# Altium schematic sheet BTT E3 SKR MINI_Power_V3.02.SchDoc("BTT E3 SKR MINI_Power_V3.02")
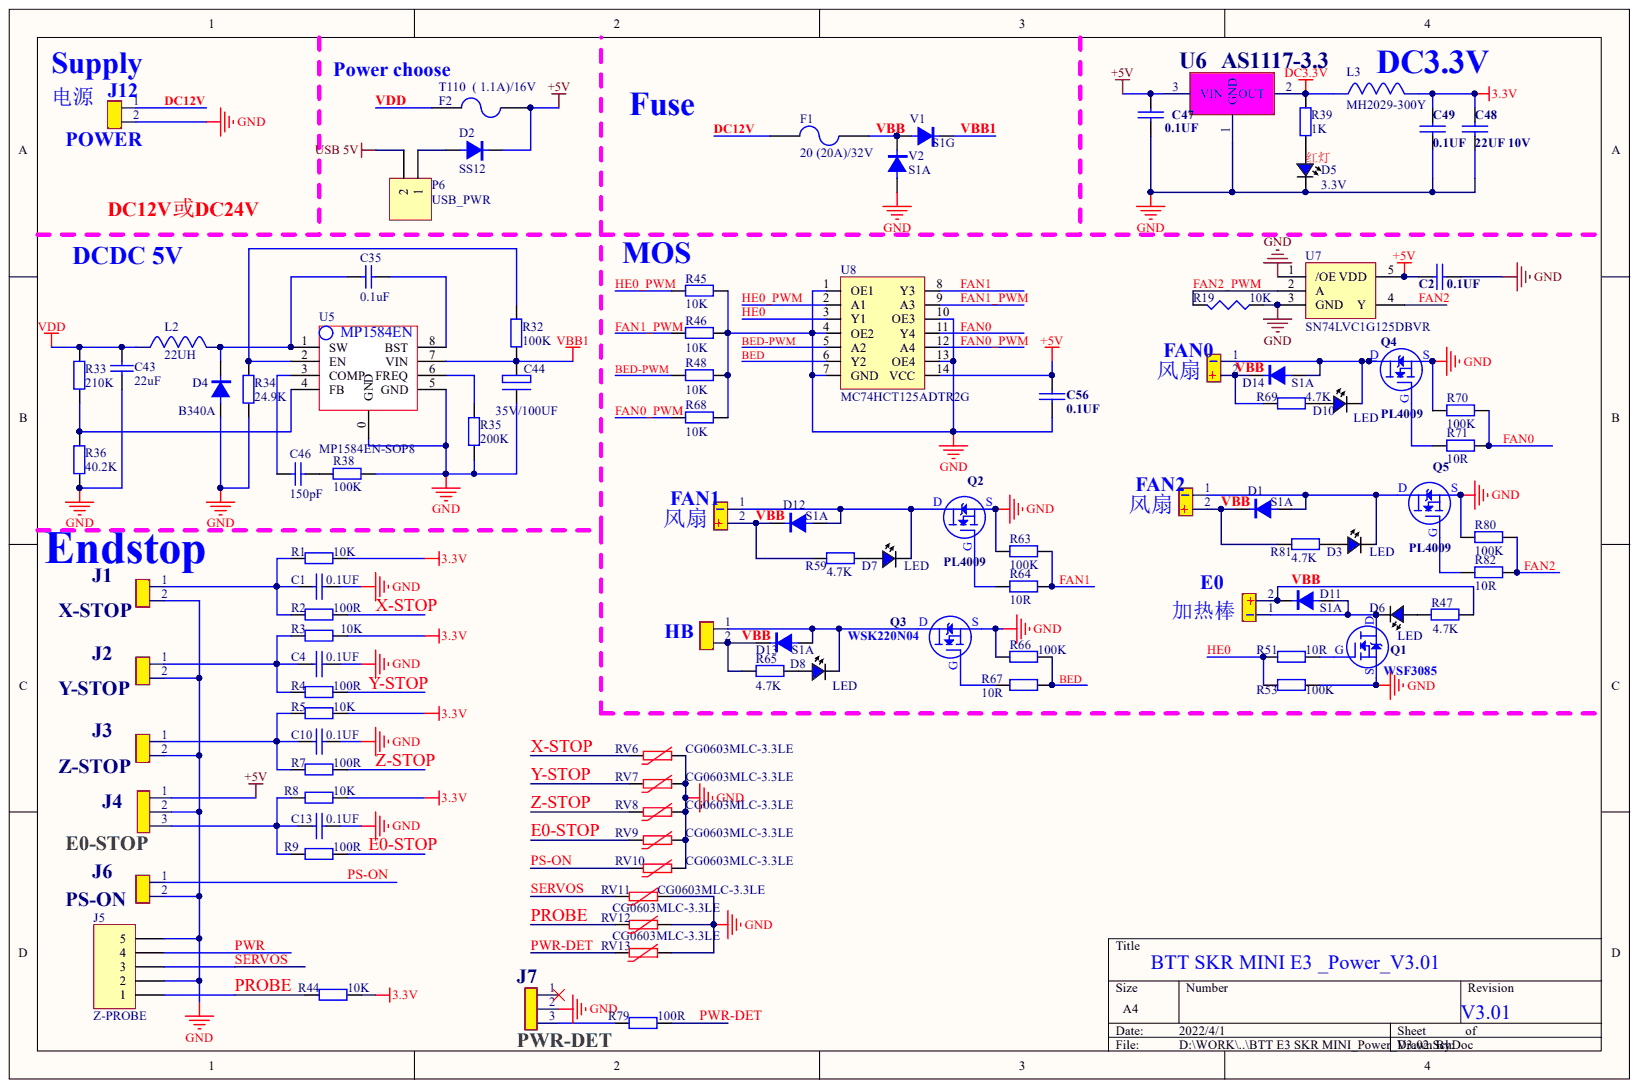
[diagram p0: BTT E3 SKR MINI_Power_V3.02.SchDoc]

## Parts
C1  0.1UF  [0603-C]
C2  0.1UF  [0603C]
C4  0.1UF  [0603-C]
C10  0.1UF  [0603-C]
C13  0.1UF  [0603-C]
C35  0.1uF  [0603-C]
C43  22uF  [0805C]
C44  35V/100UF  [100uF/35V-铝电解电容]
C46  150pF  [0603-C]
C47  0.1UF  [0603-C]
C48  22UF 10V  [0805C]
C49  0.1UF  [0603-C]
C56  0.1UF  [0603C]
D1  S1A  [DO214AC(SMA)]
D2  SS12  [DO214AC(SMA)]
D3  LED  [LED-0603-green]
D4  B340A  [DO214AC(SMA)]
D5  3.3V  [LED-0603-RED]
D6  LED  [LED-0603-green]
D7  LED  [LED-0603-green]
D8  LED  [LED-0603-green]
D10  LED  [LED-0603-green]
D11  S1A  [DO214AC(SMA)]
D12  S1A  [DO214AC(SMA)]
D13  S1A  [DO214AC(SMA)]
D14  S1A  [DO214AC(SMA)]
F1  20 (20A)/32V  [MCCQ-122 4P]
F2  T110  ( 1.1A)/16V  [1812-fuse]
FAN0  风扇  [2.54-2P-直针插座]
FAN1  风扇  [2.54-2P-直针插座]
FAN2  风扇  [2.54-2P-直针插座]
J1  X-STOP  [2.54-2P-直针插座]
J2  Y-STOP  [2.54-2P-直针插座]
J3  Z-STOP  [2.54-2P-直针插座]
J4  E0-STOP  [2.54-3P-直针插座]
J5  Z-PROBE  [2.54-5P-直针插座]
J6  PS-ON  [2.54-2P-直针插座]
J7  PWR-DET  [2.54-3P-直针插座]
J12  POWER  [KF128_2P_5.0MM]
J14  E0  [KF128_2P_5.0MM]
J15  HB  [KF128_2P_5.0MM]
L2  22UH  [5040220M电感 - duplicate]
L3  MH2029-300Y  [0805R]
P6  USB_PWR  [SIP2-2.54-BLACK]
Q1  WSF3085  [to252]
Q2  PL4009  [SOT-23-mos]
Q3  WSK220N04  [TO-263-B]
Q4  PL4009  [SOT-23-mos]
Q5  PL4009  [SOT-23-mos]
R1  10K  [0603-R]
R2  100R  [0603-R]
R3  10K  [0603-R]
R4  100R  [0603-R]
R5  10K  [0603-R]
R7  100R  [0603-R]
R8  10K  [0603-R]
R9  100R  [0603-R]
R19  10K  [0603-R]
R32  100K  [0603-R]
R33  210K  [0603-R]
R34  24.9K  [0603-R]
R35  200K  [0603-R]
R36  40.2K  [0603-R]
R38  100K  [0603-R]
R39  1K  [0603-R]
R44  10K  [0603-R]
R45  10K  [0603-R]
R46  10K  [0603-R]
R47  4.7K  [0603-R]
R48  10K  [0603-R]
R51  10R  [0603-R]
R53  100K  [0603-R]
R59  4.7K  [0603-R]
R63  100K  [0603-R]
R64  10R  [0603-R]
R65  4.7K  [0603-R]
R66  100K  [0603-R]
R67  10R  [0603-R]
R68  10K  [0603-R]
R69  4.7K  [0603-R]
R70  100K  [0603-R]
R71  10R  [0603-R]
R79  100R  [0603-R]
R80  100K  [0603-R]
R81  4.7K  [0603-R]
R82  10R  [0603-R]
RV6  CG0603MLC-3.3LE  [0603-R]
RV7  CG0603MLC-3.3LE  [0603-R]
RV8  CG0603MLC-3.3LE  [0603-R]
RV9  CG0603MLC-3.3LE  [0603-R]
RV10  CG0603MLC-3.3LE  [0603-R]
RV11  CG0603MLC-3.3LE  [0603-R]
RV12  CG0603MLC-3.3LE  [0603-R]
RV13  CG0603MLC-3.3LE  [0603-R]
U5  MP1584EN-SOP8  [MP1584EN]
U6  AS1117-3.3  [SOT-223-AMS1117]
U7  SN74LVC1G125DBVR  [sot23-5]
U8  MC74HCT125ADTR2G  [TSSOP14]
V1  S1G  [DO214AC(SMA)]
V2  S1A  [DO214AC(SMA)]

## Nets
3.3V: C48.2 C49.1 L3.2 R1.1 R3.1 R5.1 R8.1 R44.1
+5V: C2.2 C47.1 C56.2 D2.2 F2.2 J4.1 U6.3 U7.5(VDD) U8.14(VCC)
DC3.3V: L3.1 R39.1 U6.2
GND: C1.1 C2.1 C4.1 C10.1 C13.1 C43.2 C44.2 C47.2 C48.1 C49.2 C56.1 D4.1 D5.2 J1.2 J2.2 J3.2 J4.2 J5.2 J5.5 J6.2 J7.2 J12.2 Q1.S Q2.S Q3.S Q4.S Q5.S R19.1 R34.1 R35.2 R36.2 R38.1 R45.1 R46.2 R48.2 R53.2 R63.2 R66.2 R68.2 R70.2 R80.2 RV6.1 RV7.1 RV8.1 RV9.1 RV10.1 RV11.1 RV12.1 RV13.1 U5.0(GND) U5.5(GND) U6.1 U7.1(/OE) U7.3(GND) U8.1(OE1) U8.4(OE2) U8.7(GND) U8.10(OE3) U8.13(OE4) V2.1
USB 5V: P6.2
BED: R66.1 R67.1 U8.6(Y2)
BED-PWM: R48.1 U8.5(A2)
DC12V: F1.2 J12.1
E0-STOP: R9.1 RV9.2
FAN0: R70.1 R71.1 U8.11(Y4)
FAN0_PWM: R68.1 U8.12(A4)
FAN1: R63.1 R64.1 U8.8(Y3)
FAN1_PWM: R46.1 U8.9(A3)
FAN2: R80.1 R82.1 U7.4(Y)
FAN2_PWM: R19.2 U7.2(A)
HE0: R51.1 R53.1 U8.3(Y1)
HE0_PWM: R45.2 U8.2(A1)
PROBE: J5.1 R44.2 RV12.2
PS-ON: J6.1 RV10.2
PWR: J5.4
PWR-DET: R79.1 RV13.2
SERVOS: J5.3 RV11.2
VBB: D1.2 D11.2 D12.2 D13.2 D14.2 F1.1 FAN0.2 FAN1.2 FAN2.2 J14.2 J15.2 R47.2 R59.1 R65.1 R69.1 R81.1 V1.1 V2.2
VBB1: C44.1 R32.1 U5.7(VIN) V1.2
VDD: C43.1 F2.1 L2.1 R33.2
X-STOP: R2.1 RV6.2
Y-STOP: R4.1 RV7.2
Z-STOP: R7.1 RV8.2
NetC1_2: C1.2 J1.1 R1.2 R2.2
NetC4_2: C4.2 J2.1 R3.2 R4.2
NetC10_2: C10.2 J3.1 R5.2 R7.2
NetC13_2: C13.2 J4.3 R8.2 R9.2
NetC35_1: C35.1 U5.8(BST)
NetC35_2: C35.2 D4.2 L2.2 U5.1(SW)
NetC46_1: C46.1 R38.2
NetC46_2: C46.2 U5.3(COMP)
NetD1_1: D1.1 D3.2 FAN2.1 Q5.D
NetD2_1: D2.1 P6.1
NetD3_1: D3.1 R81.2
NetD5_1: D5.1 R39.2
NetD6_1: D6.1 R47.1
NetD6_2: D6.2 D11.1 J14.1 Q1.D
NetD7_1: D7.1 R59.2
NetD7_2: D7.2 D12.1 FAN1.1 Q2.D
NetD8_1: D8.1 R65.2
NetD8_2: D8.2 D13.1 J15.1 Q3.D
NetD10_1: D10.1 R69.2
NetD10_2: D10.2 D14.1 FAN0.1 Q4.D
NetJ7_3: J7.3 R79.2
NetQ1_G: Q1.G R51.2
NetQ2_G: Q2.G R64.2
NetQ3_G: Q3.G R67.2
NetQ4_G: Q4.G R71.2
NetQ5_G: Q5.G R82.2
NetR32_2: R32.2 R34.2 U5.2(EN)
NetR33_1: R33.1 R36.1 U5.4(FB)
NetR35_1: R35.1 U5.6(FREQ)

Not connected: J7 1

## Library data of the parts
C1 C2 C4 C10 C13 C47-C49 C56 = CAP: Component Kind: Standard; Library Name: liroqi.SchLib
C35 C43 C46 = Cap: Capacitor; Component Kind: Standard; LatestRevisionDate: 17-Jul-2002; LatestRevisionNote: Re-released for DXP Platform.; PackageDescription: Radial Cap, Thru-Hole; 2 Leads; 0.3 in Pin Spacing; PackageReference: RAD-0.3; Published: 8-Jun-2000; Signal Integrity: Cap; Simulation: CAP; Library Name: 常用元器件.SchLib; Publisher: Altium Limited
  C35: Value: 0.1uF
  C43: Value: 22uF
  C46: Value: 150pF
C44 = C: Component Kind: Standard; Library Name: liroqi.SchLib
D1 D2 D4 D11-D14 V1 V2 = DIODE: Component Kind: Standard
  D1 D11-D14: Library Name: 常用库.SCHLIB
  D2 D4 V1 V2: Library Name: liroqi.SchLib
D5 = LED: Component Kind: Standard; Library Name: liroqi.SchLib
F1 F2 = FUSE2: Fuse; Component Kind: Standard; Library Name: My Useful Discrete Devices.SchLib
FAN0-FAN2 J1-J3 J6 J12 J14 J15 = SIP2: Component Kind: Standard; Library Name: liroqi.SchLib
J4 J7 = SIP3: Component Kind: Standard; Library Name: liroqi.SchLib
J5 = Header 5: Header, 5-Pin; Component Kind: Standard; LatestRevisionDate: 17-Jul-2002; LatestRevisionNote: Re-released for DXP Platform.; Library Name: Miscellaneous Connectors.IntLib; Signal Integrity: Connector; Publisher: Altium Limited
L2 L3 = L: Component Kind: Standard; Library Name: liroqi.SchLib
P6 = Header 2: Header, 2-Pin; Component Kind: Standard; LatestRevisionDate: 17-Jul-2002; LatestRevisionNote: Re-released for DXP Platform.; Library Name: Miscellaneous Connectors.IntLib; Signal Integrity: Connector; Publisher: Altium Limited
Q1-Q5 = VS3060AD: Component Kind: Standard; Library Name: liroqi.SchLib
R1-R5 R7-R9 R32 R34-R36 R39 R45-R48 R51 R53 R59 R63-R65 R67-R71 R79-R82 = Res2: Resistor; Component Kind: Standard; LatestRevisionDate: 17-Jul-2002; LatestRevisionNote: Re-released for DXP Platform.; PackageDescription: Axial Device, Thru-Hole; 2 Leads; 0.4 in Pin Spacing; PackageReference: AXIAL-0.4; Published: 8-Jun-2000; Signal Integrity: Res; Simulation: RESISTOR; Publisher: Altium Limited
  R1 R3 R5 R8 R45 R46 R48 R68: Value: 10K
  R2 R4 R7 R9 R79: Value: 100R
  R32 R53 R63 R70 R80: Value: 100K
  R34: Value: 24.9K
  R35: Value: 200K
  R36: Value: 40.2K
  R39: Value: 1K
  R47 R59 R65 R69 R81: Value: 4.7K
  R51 R64 R67 R71 R82: Value: 10R
  R1-R5 R7-R9 R39 R45-R48 R51 R53 R59 R63-R65 R67-R71 R79-R82: Library Name: BTT E3 SKR MINI V3.IntLib
  R32 R34-R36: Library Name: 常用元器件.SchLib
R19 = RES1: Component Kind: Standard; Library Name: My Usefu Discrete Devices.SchLib
R33 = Res2: Resistor; Value: 562K; Component Kind: Standard; LatestRevisionDate: 17-Jul-2002; LatestRevisionNote: Re-released for DXP Platform.; PackageDescription: Axial Device, Thru-Hole; 2 Leads; 0.4 in Pin Spacing; PackageReference: AXIAL-0.4; Published: 8-Jun-2000; Signal Integrity: Res; Simulation: RESISTOR; Library Name: 常用元器件.SchLib; Publisher: Altium Limited
R38 = Res2: Resistor; Value: 147K; Component Kind: Standard; LatestRevisionDate: 17-Jul-2002; LatestRevisionNote: Re-released for DXP Platform.; PackageDescription: Axial Device, Thru-Hole; 2 Leads; 0.4 in Pin Spacing; PackageReference: AXIAL-0.4; Published: 8-Jun-2000; Signal Integrity: Res; Simulation: RESISTOR; Library Name: 常用元器件.SchLib; Publisher: Altium Limited
R44 = Res2: Resistor; Value: 100R; Component Kind: Standard; LatestRevisionDate: 17-Jul-2002; LatestRevisionNote: Re-released for DXP Platform.; PackageDescription: Axial Device, Thru-Hole; 2 Leads; 0.4 in Pin Spacing; PackageReference: AXIAL-0.4; Published: 8-Jun-2000; Signal Integrity: Res; Simulation: RESISTOR; Library Name: BTT E3 SKR MINI V3.IntLib; Publisher: Altium Limited
R66 = Res2: Resistor; Value: 10K; Component Kind: Standard; LatestRevisionDate: 17-Jul-2002; LatestRevisionNote: Re-released for DXP Platform.; PackageDescription: Axial Device, Thru-Hole; 2 Leads; 0.4 in Pin Spacing; PackageReference: AXIAL-0.4; Published: 8-Jun-2000; Signal Integrity: Res; Simulation: RESISTOR; Library Name: BTT E3 SKR MINI V3.IntLib; Publisher: Altium Limited
RV6-RV13 = CG0603MLC-05E: VAR 0603 5V; Manufacturer: YAGEO; EE_SPEC: 5VDC; Tolerance: NA; Component Kind: Standard; DISTRIBUTOR: Digi-Key; DISTRIBUTOR #: CG0603MLC-05ETR-ND; Library Name: 3Delight.SCHLIB; MANUFACTURER #: CG0603MLC-05E; PART: 5VDC; Part Description: VARISTOR 0603; Signal Integrity: Model Name; Simulation: Model Name; VALUE: 5V
U6 = AMS1117-3.3: Component Kind: Standard; Library Name: liroqi.SchLib
U7 = SN74LVC1G125DBVR: 单路缓冲器; Component Kind: Standard; Library Name: My Useful IC.SchLib
D3 D6-D8 D10 = LED: Component Kind: Standard; Library Name: liroqi.SchLib
U5 = MP1584EN-SOP8: Component Kind: Standard; Library Name: 常用元器件.SchLib
U8 = MC74HCT125ADTR2G: Component Kind: Standard; Description: MC74HCT125ADTR2G; Library Name: IC.SchLib
